# Altium schematic sheet USART_CAN.SchDoc
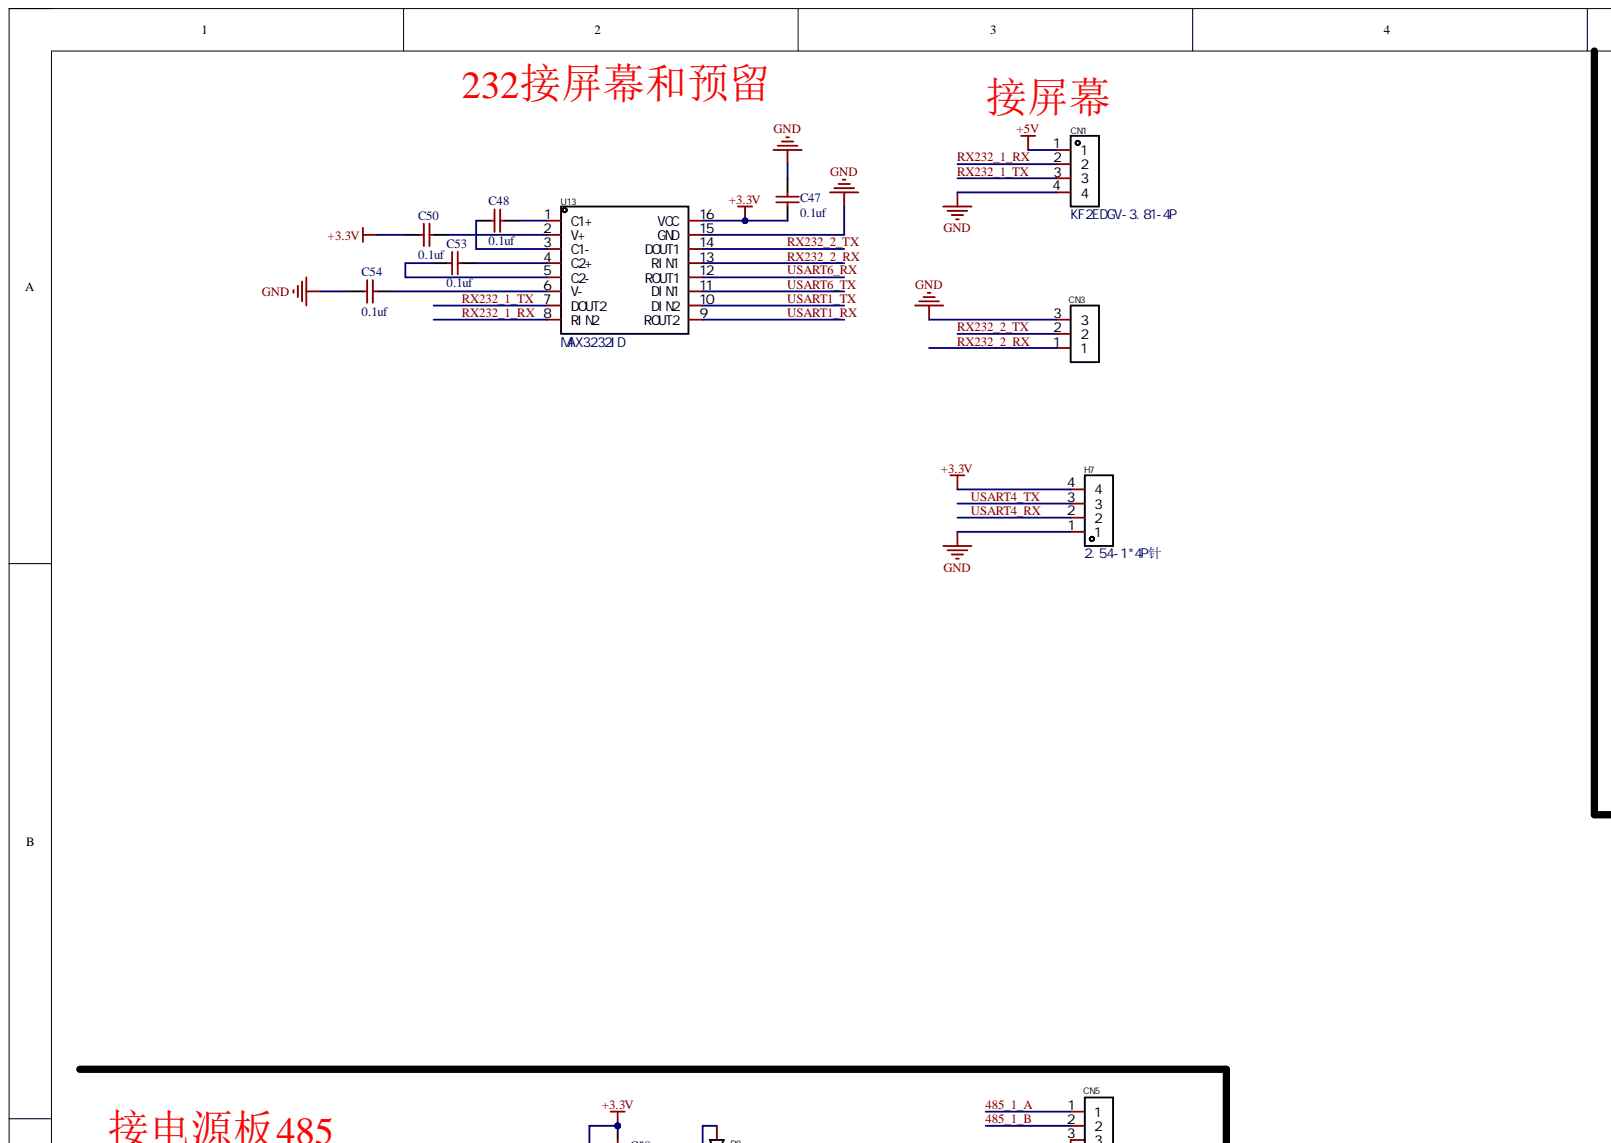
[diagram p0: USART_CAN.SchDoc, top left]
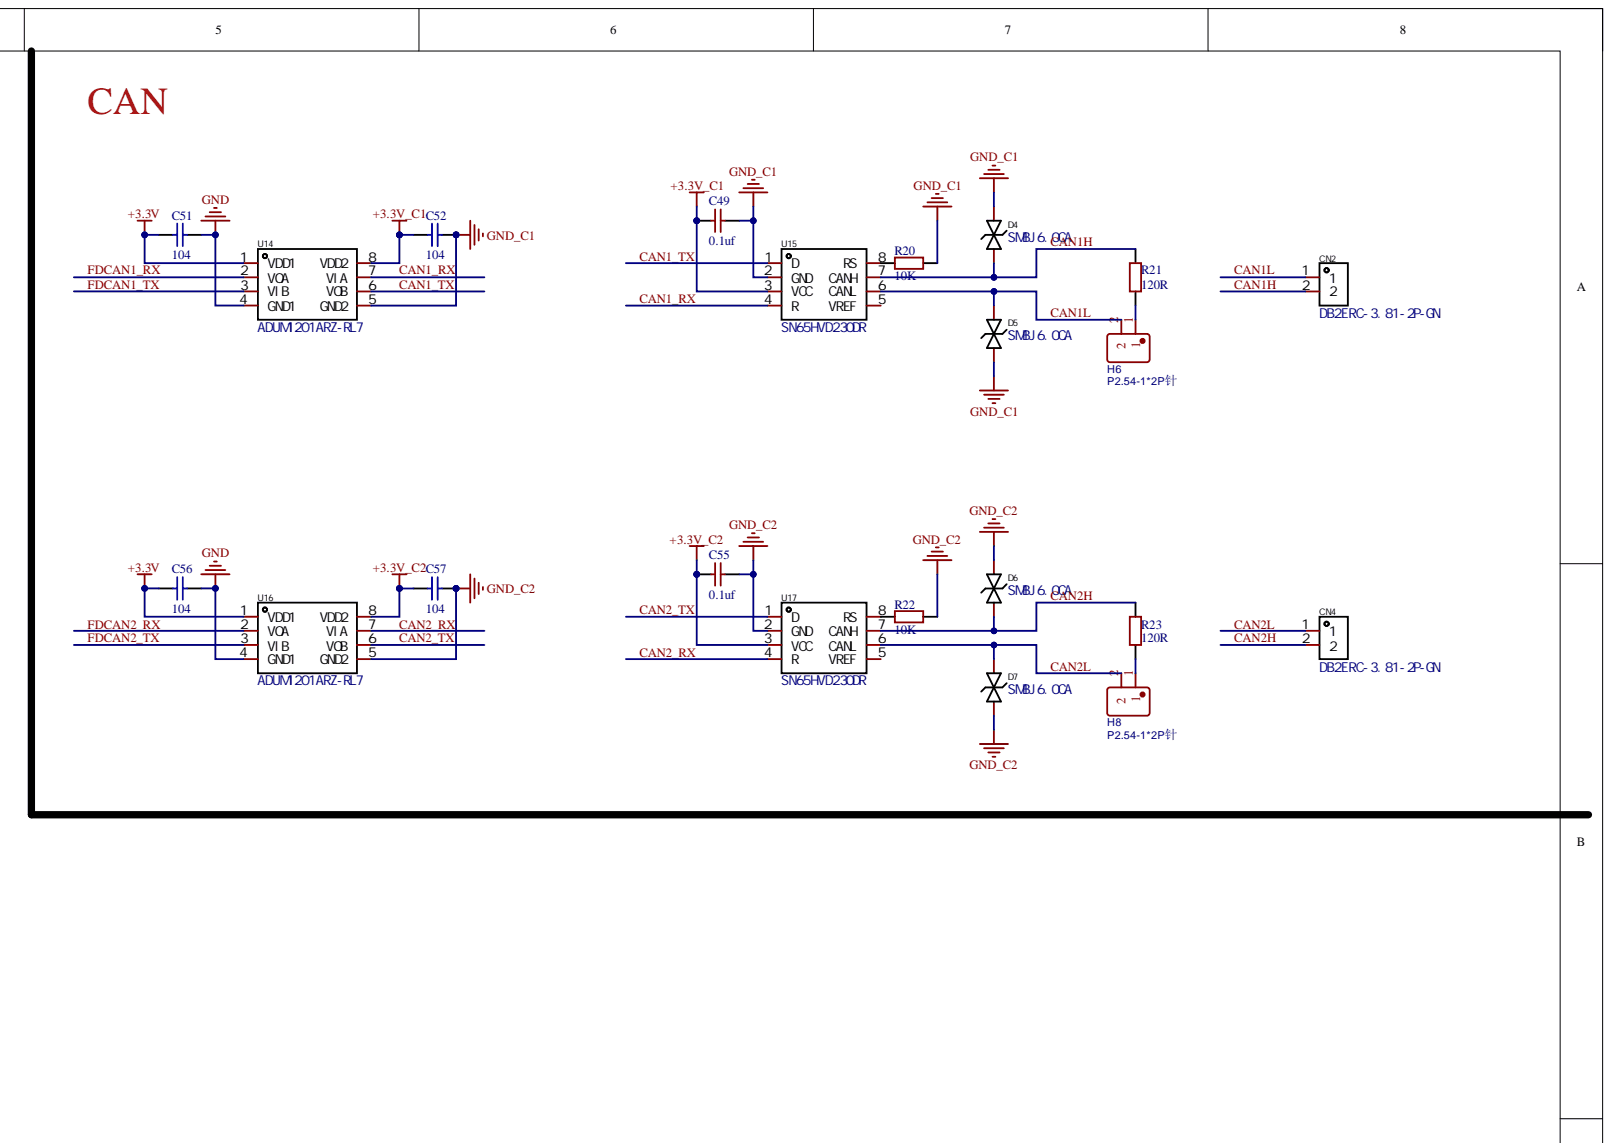
[diagram p1: USART_CAN.SchDoc, top right]
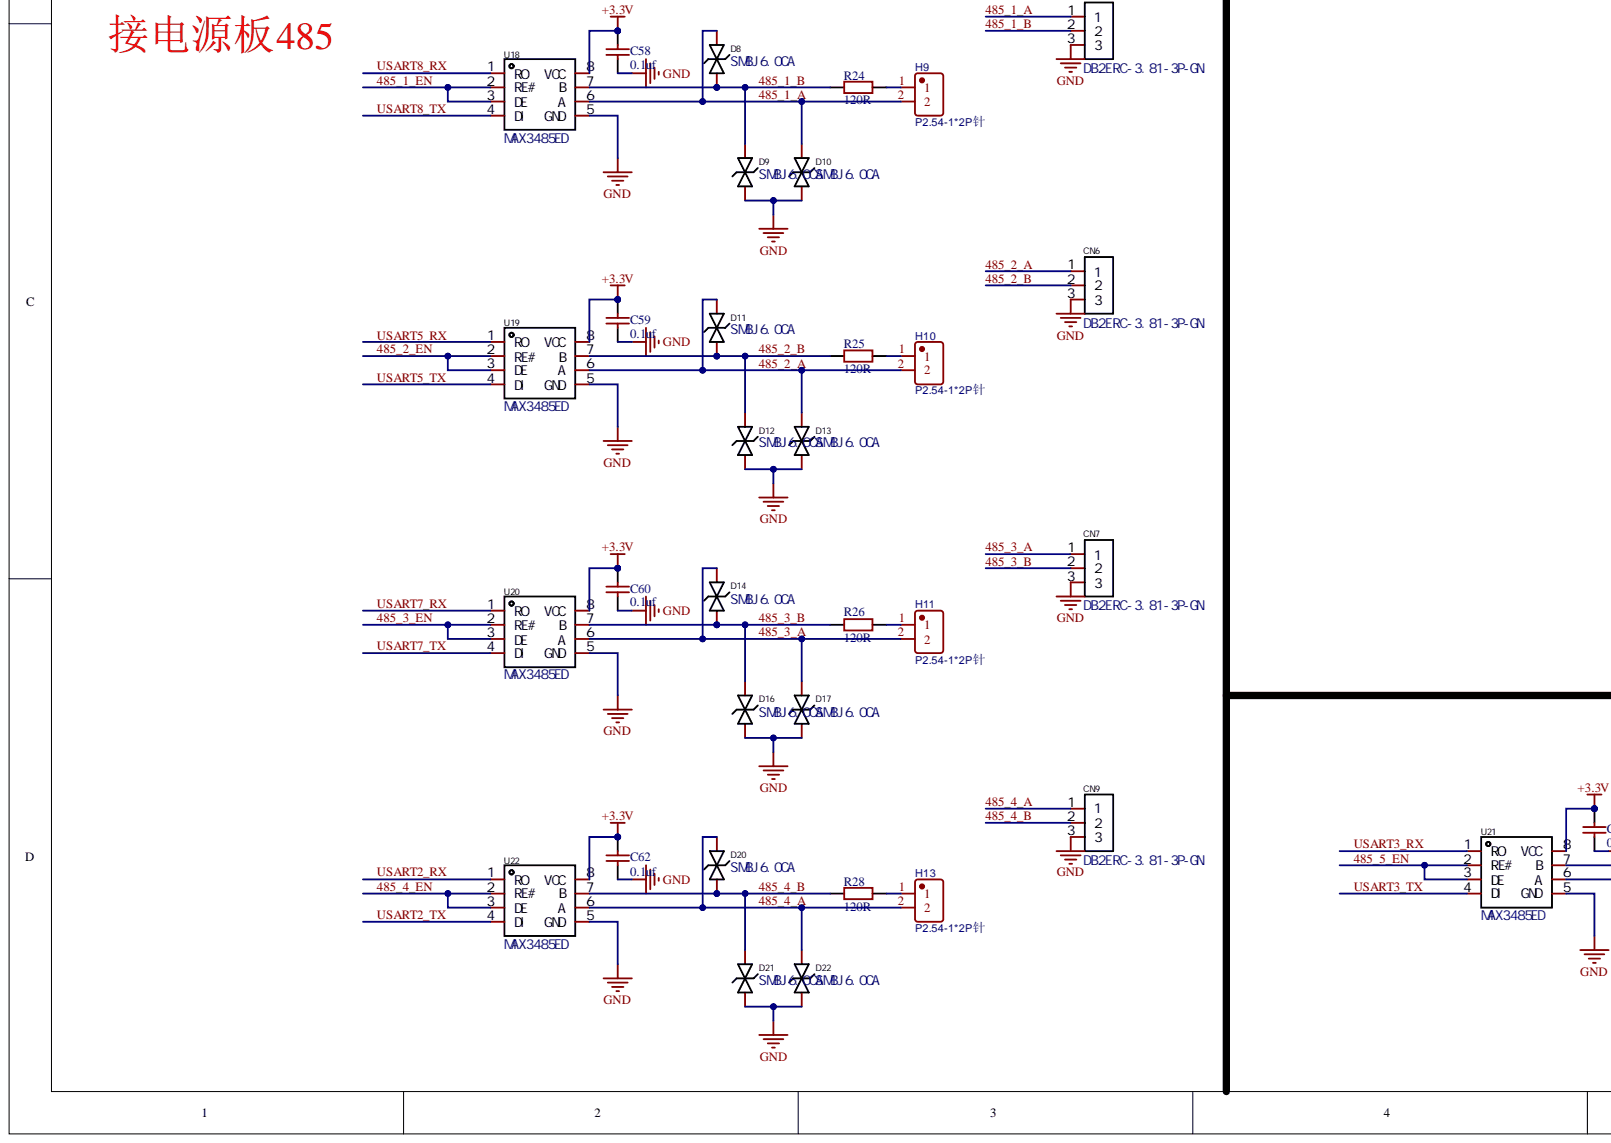
[diagram p2: USART_CAN.SchDoc, bottom left]
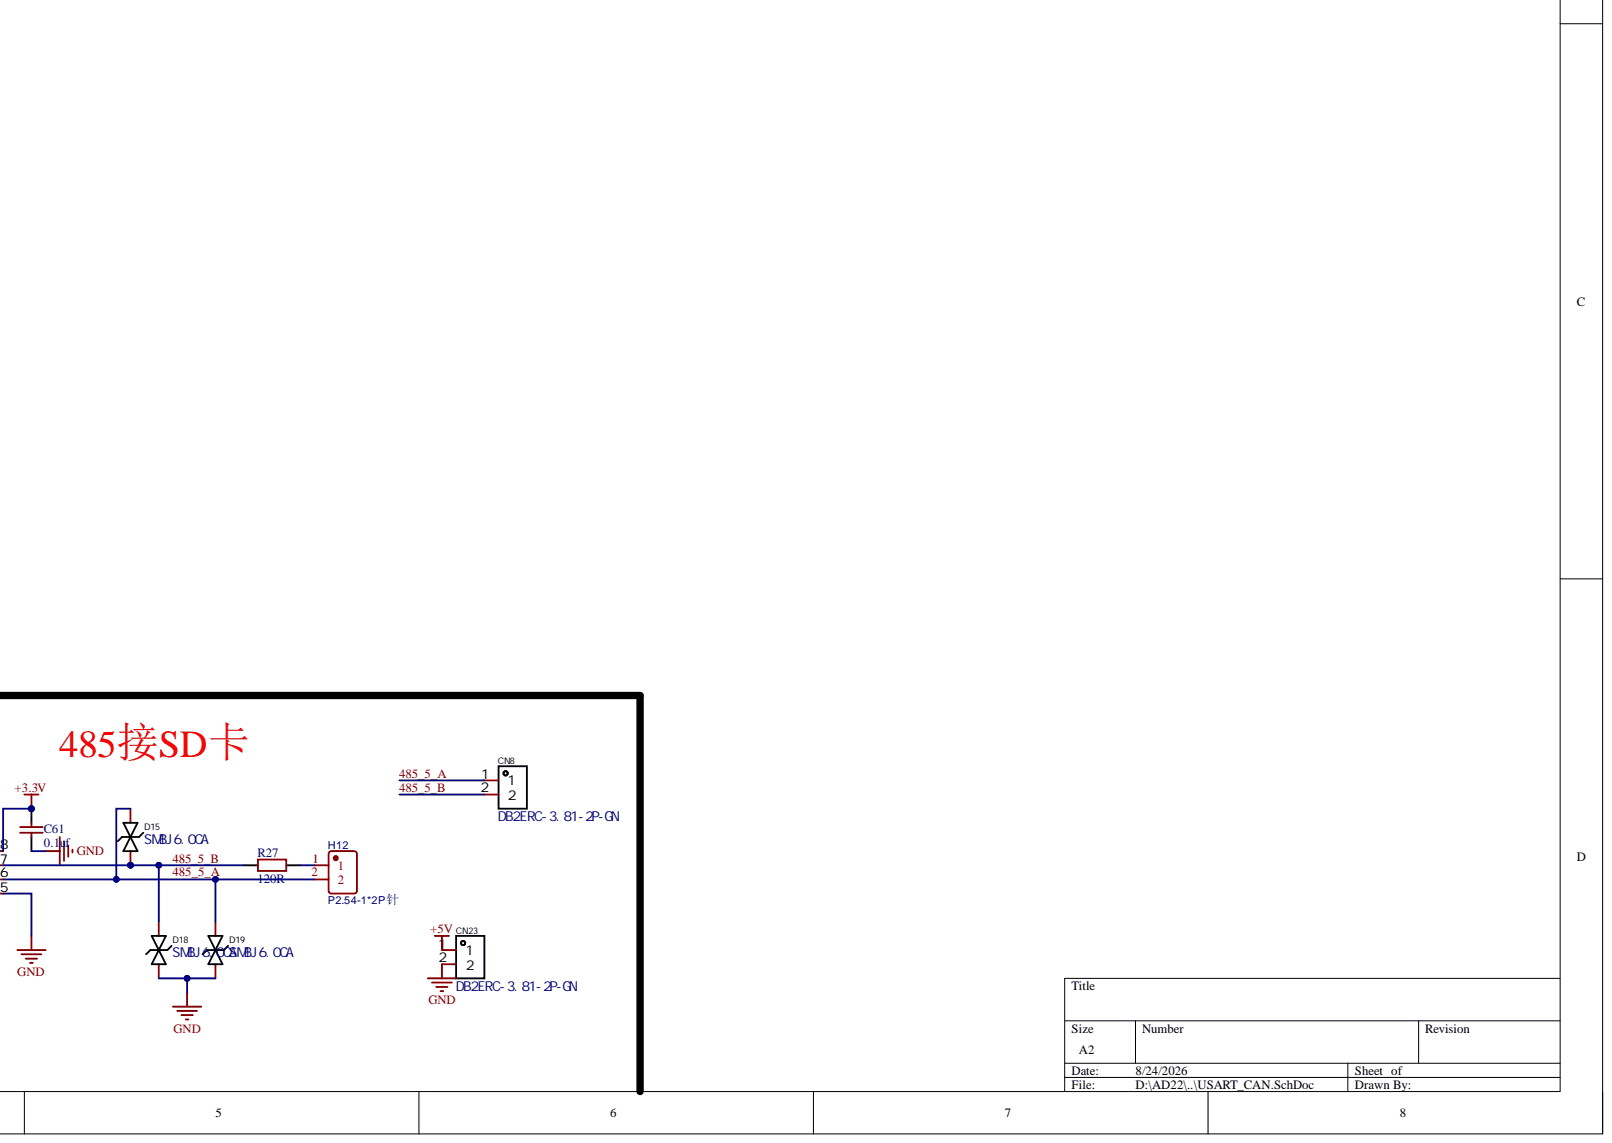
[diagram p3: USART_CAN.SchDoc, bottom right]

## Parts
C47  0.1uf  [0805_C]
C48  0.1uf  [0805_C]
C49  0.1uf  [0805_C]
C50  0.1uf  [0805_C]
C51  104  [0805_C]
C52  104  [0805_C]
C53  0.1uf  [0805_C]
C54  0.1uf  [0805_C]
C55  0.1uf  [0805_C]
C56  104  [0805_C]
C57  104  [0805_C]
C58  0.1uf  [0805_C]
C59  0.1uf  [0805_C]
C60  0.1uf  [0805_C]
C61  0.1uf  [0805_C]
C62  0.1uf  [0805_C]
CN1  KF2EDGV-3.81-4P  [CONN-TH_4P-P3.81_KF2EDGV-3.81-4P]
CN2  DB2ERC-3.81-2P-GN  [CONN-TH_2P-P3.81_DB2ERC-3.81-2P]
CN3  (no value)  [CONN-TH_3P-P3.81_DB2ERC-3.81-3P]
CN4  DB2ERC-3.81-2P-GN  [CONN-TH_2P-P3.81_DB2ERC-3.81-2P]
CN5  DB2ERC-3.81-3P-GN  [CONN-TH_3P-P3.81_DB2ERC-3.81-3P]
CN6  DB2ERC-3.81-3P-GN  [CONN-TH_3P-P3.81_DB2ERC-3.81-3P]
CN7  DB2ERC-3.81-3P-GN  [CONN-TH_3P-P3.81_DB2ERC-3.81-3P]
CN8  DB2ERC-3.81-2P-GN  [CONN-TH_2P-P3.81_DB2ERC-3.81-2P]
CN9  DB2ERC-3.81-3P-GN  [CONN-TH_3P-P3.81_DB2ERC-3.81-3P]
CN23  DB2ERC-3.81-2P-GN  [CONN-TH_2P-P3.81_DB2ERC-3.81-2P]
D4  SMBJ6.0CA  [SMB_L4.4-W3.6-LS5.3-BI]
D5  SMBJ6.0CA  [SMB_L4.4-W3.6-LS5.3-BI]
D6  SMBJ6.0CA  [SMB_L4.4-W3.6-LS5.3-BI]
D7  SMBJ6.0CA  [SMB_L4.4-W3.6-LS5.3-BI]
D8  SMBJ6.0CA  [SMB_L4.4-W3.6-LS5.3-BI]
D9  SMBJ6.0CA  [SMB_L4.4-W3.6-LS5.3-BI]
D10  SMBJ6.0CA  [SMB_L4.4-W3.6-LS5.3-BI]
D11  SMBJ6.0CA  [SMB_L4.4-W3.6-LS5.3-BI]
D12  SMBJ6.0CA  [SMB_L4.4-W3.6-LS5.3-BI]
D13  SMBJ6.0CA  [SMB_L4.4-W3.6-LS5.3-BI]
D14  SMBJ6.0CA  [SMB_L4.4-W3.6-LS5.3-BI]
D15  SMBJ6.0CA  [SMB_L4.4-W3.6-LS5.3-BI]
D16  SMBJ6.0CA  [SMB_L4.4-W3.6-LS5.3-BI]
D17  SMBJ6.0CA  [SMB_L4.4-W3.6-LS5.3-BI]
D18  SMBJ6.0CA  [SMB_L4.4-W3.6-LS5.3-BI]
D19  SMBJ6.0CA  [SMB_L4.4-W3.6-LS5.3-BI]
D20  SMBJ6.0CA  [SMB_L4.4-W3.6-LS5.3-BI]
D21  SMBJ6.0CA  [SMB_L4.4-W3.6-LS5.3-BI]
D22  SMBJ6.0CA  [SMB_L4.4-W3.6-LS5.3-BI]
H6  P2.54-1*2P针  [HDR-TH_2P-P2.54-V-M]
H7  2.54-1*4P针  [HDR-TH_4P-P2.54-V-M]
H8  P2.54-1*2P针  [HDR-TH_2P-P2.54-V-M]
H9  P2.54-1*2P针  [HDR-TH_2P-P2.54-V-M]
H10  P2.54-1*2P针  [HDR-TH_2P-P2.54-V-M]
H11  P2.54-1*2P针  [HDR-TH_2P-P2.54-V-M]
H12  P2.54-1*2P针  [HDR-TH_2P-P2.54-V-M]
H13  P2.54-1*2P针  [HDR-TH_2P-P2.54-V-M]
R20  10K  [0805_R]
R21  120R  [0805_R]
R22  10K  [0805_R]
R23  120R  [0805_R]
R24  120R  [0805_R]
R25  120R  [0805_R]
R26  120R  [0805_R]
R27  120R  [0805_R]
R28  120R  [0805_R]
U13  MAX3232ID  [SOIC-16_L9.9-W3.9-P1.27-LS6.0-BL]
U14  ADUM1201ARZ-RL7  [SOIC-8_L4.9-W3.9-P1.27-LS6.0-BL]
U15  SN65HVD230DR  [SOIC-8_L4.9-W3.9-P1.27-LS6.0-BL]
U16  ADUM1201ARZ-RL7  [SOIC-8_L4.9-W3.9-P1.27-LS6.0-BL]
U17  SN65HVD230DR  [SOIC-8_L4.9-W3.9-P1.27-LS6.0-BL]
U18  MAX3485ED  [SOP-8_L4.9-W3.9-P1.27-LS6.0-BL]
U19  MAX3485ED  [SOP-8_L4.9-W3.9-P1.27-LS6.0-BL]
U20  MAX3485ED  [SOP-8_L4.9-W3.9-P1.27-LS6.0-BL]
U21  MAX3485ED  [SOP-8_L4.9-W3.9-P1.27-LS6.0-BL]
U22  MAX3485ED  [SOP-8_L4.9-W3.9-P1.27-LS6.0-BL]

## Nets
+3.3V: C47.2 C50.2 C51.2 C56.2 C58.1 C59.1 C60.1 C61.1 C62.1 H7.4 U13.16(VCC) U14.1(VDD1) U16.1(VDD1) U18.8(VCC) U19.8(VCC) U20.8(VCC) U21.8(VCC) U22.8(VCC)
+3.3V_C1: C49.2 C52.2 U14.8(VDD2) U15.3(VCC)
+3.3V_C2: C55.2 C57.2 U16.8(VDD2) U17.3(VCC)
+5V: CN1.1 CN23.1
GND: C47.1 C51.1 C54.2 C56.1 C58.2 C59.2 C60.2 C61.2 C62.2 CN1.4 CN3.3 CN5.3 CN6.3 CN7.3 CN9.3 CN23.2 D9.1 D10.1 D12.1 D13.1 D16.1 D17.1 D18.1 D19.1 D21.1 D22.1 H7.1 U13.15(GND) U14.4(GND1) U16.4(GND1) U18.5(GND) U19.5(GND) U20.5(GND) U21.5(GND) U22.5(GND)
GND_C1: C49.1 C52.1 D4.2 D5.1 R20.1 U14.5(GND2) U15.2(GND)
GND_C2: C55.1 C57.1 D6.2 D7.1 R22.1 U16.5(GND2) U17.2(GND)
485_1_A: CN5.1 D8.2 D10.2 H9.2 U18.6(A)
485_1_B: CN5.2 D8.1 D9.2 R24.1 U18.7(B)
485_1_EN: U18.2(RE#) U18.3(DE)
485_2_A: CN6.1 D11.2 D13.2 H10.2 U19.6(A)
485_2_B: CN6.2 D11.1 D12.2 R25.1 U19.7(B)
485_2_EN: U19.2(RE#) U19.3(DE)
485_3_A: CN7.1 D14.2 D17.2 H11.2 U20.6(A)
485_3_B: CN7.2 D14.1 D16.2 R26.1 U20.7(B)
485_3_EN: U20.2(RE#) U20.3(DE)
485_4_A: CN9.1 D20.2 D22.2 H13.2 U22.6(A)
485_4_B: CN9.2 D20.1 D21.2 R28.1 U22.7(B)
485_4_EN: U22.2(RE#) U22.3(DE)
485_5_A: CN8.1 D15.2 D19.2 H12.2 U21.6(A)
485_5_B: CN8.2 D15.1 D18.2 R27.1 U21.7(B)
485_5_EN: U21.2(RE#) U21.3(DE)
CAN1_RX: U14.7(VIA) U15.4(R)
CAN1_TX: U14.6(VOB) U15.1(D)
CAN1H: CN2.2 D4.1 R21.2 U15.7(CANH)
CAN1L: CN2.1 D5.2 H6.2 U15.6(CANL)
CAN2_RX: U16.7(VIA) U17.4(R)
CAN2_TX: U16.6(VOB) U17.1(D)
CAN2H: CN4.2 D6.1 R23.2 U17.7(CANH)
CAN2L: CN4.1 D7.2 H8.2 U17.6(CANL)
FDCAN1_RX: U14.2(VOA)
FDCAN1_TX: U14.3(VIB)
FDCAN2_RX: U16.2(VOA)
FDCAN2_TX: U16.3(VIB)
RX232_1_RX: CN1.2 U13.8(RIN2)
RX232_1_TX: CN1.3 U13.7(DOUT2)
RX232_2_RX: CN3.1 U13.13(RIN1)
RX232_2_TX: CN3.2 U13.14(DOUT1)
USART1_RX: U13.9(ROUT2)
USART1_TX: U13.10(DIN2)
USART2_RX: U22.1(RO)
USART2_TX: U22.4(DI)
USART3_RX: U21.1(RO)
USART3_TX: U21.4(DI)
USART4_RX: H7.2
USART4_TX: H7.3
USART5_RX: U19.1(RO)
USART5_TX: U19.4(DI)
USART6_RX: U13.12(ROUT1)
USART6_TX: U13.11(DIN1)
USART7_RX: U20.1(RO)
USART7_TX: U20.4(DI)
USART8_RX: U18.1(RO)
USART8_TX: U18.4(DI)
NetC48_1: C48.1 U13.1(C1+)
NetC48_2: C48.2 U13.3(C1-)
NetC50_1: C50.1 U13.2(V+)
NetC53_1: C53.1 U13.4(C2+)
NetC53_2: C53.2 U13.5(C2-)
NetC54_1: C54.1 U13.6(V-)
NetH6_1: H6.1 R21.1
NetH8_1: H8.1 R23.1
NetH9_1: H9.1 R24.2
NetH10_1: H10.1 R25.2
NetH11_1: H11.1 R26.2
NetH12_1: H12.1 R27.2
NetH13_1: H13.1 R28.2
NetR20_2: R20.2 U15.8(RS)
NetR22_2: R22.2 U17.8(RS)

Not connected: U15 5(VREF); U17 5(VREF)

## Library data of the parts
C47-C50 C53-C55 C58-C62 = C 0603 1.0pF (010) 0.25pF 50V: 贴片电容; Component Kind: Standard; ComponentLink1Description: 供应商链接; ComponentLink1URL: http://www.szlcsc.com/product/details_24709.html; Library Name: BasicComponents2205.IntLib; Package: 0603; Supplier: LC; SuppliersPartNumber: C23969
C51 C52 C56 C57 = 0805 100nF(104) 10% 50V: 贴片电容; Component Kind: Standard; ComponentLink1Description: 供应商链接; ComponentLink1URL: http://www.szlcsc.com/product/details_50686.html; Package: 0805; Supplier: LC; SuppliersPartNumber: C49678; Library Name: JiaLiChuang.IntLib
CN1 = KF2EDGV-3.81-4P: 插拔式接线端子3.81 立式; Manufacturer: KEFA(科发); Add into BOM: yes; Connector Type: 板端/插座-闭口; Current Rating (Max): 8A; Device: KF2EDGV-3.81-4P_C441334; Number of PINs Per Row: 4; Pitch: 3.81mm; Structure: 1x4P; Voltage Rating (Max): 300V; Color: 绿色; Component Kind: Standard; Convert to PCB: yes; Datasheet: https://atta.szlcsc.com/upload/public/pdf/source/20230331/99AB14A2E5FD5FFB40E14C447652EA65.pdf; JLCPCB Part Class: Extended Part; LCSC Part Name: 3.81mm 1x4P 排数:1 每排P数:4; Library Name: pro24.IntLib; Manufacturer Part: KF2EDGV-3.81-4P; Mounting Style: 直针; Name: KF2EDGV-3.81-4P; Number of Pins: 4P; Number of Rows: 1; Operating Temperature Range: -40℃~+105℃; Supplier: LCSC; Supplier Footprint: 插件,P=3.81mm; Supplier Part: C441334; Symbol: KF2EDGV-3.81-4P
CN2 CN4 CN8 CN23 = DB2ERC-3.81-2P-GN: 插拔式接线端子3.81 立式/卧式; Manufacturer: DIBO(地博电气); Add into BOM: yes; Connector Type: 板端/插座-闭口; Current Rating (Max): 10A; Number of PINs Per Row: 2; Pitch: 3.81mm; Structure: 1x2P; Voltage Rating (Max): 250V; Color: 绿色; Component Kind: Standard; Convert to PCB: yes; Datasheet: https://atta.szlcsc.com/upload/public/pdf/source/20221115/4E217E7D538220F8720C137D6A05E519.pdf; Device: DB2ERC-3.81-2P-GN; JLCPCB Part Class: Extended Part; LCSC Part Name: 板端/插座-闭口 1x2P 3.81mm 排数:1 每排P数:2 弯针; Library Name: pro24.IntLib; Manufacturer Part: DB2ERC-3.81-2P-GN; Mounting Style: 弯针; Name: DB2ERC-3.81-2P-GN; Number of Rows: 1; Operating Temperature Range: -40℃~+105℃; Supplier: LCSC; Supplier Footprint: 弯插,P=3.81mm; Supplier Part: C395684; Symbol: DB2ERC-3.81-2P
CN3 CN5-CN7 CN9 = DB2ERC-3.81-3P-GN: 插拔式接线端子3.81 立式/卧式; Manufacturer: DIBO(地博电气); Add into BOM: yes; Connector Type: 板端/插座-闭口; Current Rating (Max): 10A; Device: DB2ERC-3.81-2P-GN; Number of PINs Per Row: 2; Pitch: 3.81mm; Structure: 1x2P; Symbol: DB2ERC-3.81-2P; Voltage Rating (Max): 250V; Color: 绿色; Component Kind: Standard; Convert to PCB: yes; Datasheet: https://atta.szlcsc.com/upload/public/pdf/source/20221115/4E217E7D538220F8720C137D6A05E519.pdf; JLCPCB Part Class: Extended Part; LCSC Part Name: 板端/插座-闭口 1x2P 3.81mm 排数:1 每排P数:2 弯针; Library Name: pro24.IntLib; Manufacturer Part: DB2ERC-3.81-2P-GN; Mounting Style: 弯针; Name: DB2ERC-3.81-2P-GN; Number of Rows: 1; Operating Temperature Range: -40℃~+105℃; Supplier: LCSC; Supplier Footprint: 弯插,P=3.81mm; Supplier Part: C395684
D4-D22 = SMBJ6.0CA: 瞬态抑制二极管(TVS) 双向; Manufacturer: TWGMC(台湾迪嘉); Add into BOM: yes; Device: SMBJ6.0CA_C726852; Component Kind: Standard; Convert to PCB: yes; Datasheet: https://atta.szlcsc.com/upload/public/pdf/source/20200901/C726811_07201DC3516E92FF13903A0BAE18F725.pdf; JLCPCB Part Class: Extended Part; LCSC Part Name: SMBJ6.0CA; Library Name: pro24.IntLib; Manufacturer Part: SMBJ6.0CA; Name: SMBJ6.0CA; Supplier: LCSC; Supplier Footprint: SMB; Supplier Part: C726852; Symbol: SMBJ6.0CA_C726852
H6 H8-H13 = P2.54-1*2P针: https://list.szlcsc.com/catalog/644.html; Component Kind: Standard; Library Name: BasicComponents2205.IntLib
H7 = 2.54-1*4P针: 排针; Manufacturer: XFCN(兴飞); Add into BOM: yes; Circluar Pins/Square Pins: 方针; Current Rating (Max): 3A; Device: PZ254V-11-04P; Pin Structure: 1x4P; Pitch: 2.54mm; Symbol: PZ254V-11-04P_C2691448; Voltage Rating (Max): 250V; Color: 黑色; Component Kind: Standard; Contact Material: -; Convert to PCB: yes; Datasheet: https://atta.szlcsc.com/upload/public/pdf/source/20200309/C492403_F9F19131791D08044AD4F84F8E751A34.pdf; Insulation Height: 2.5mm; JLCPCB Part Class: Extended Part; LCSC Part Name: 2.54mm 1x4P 直插 方针; Length of End Connection Pin: 3mm; Length of Mating Pin: 6mm; Manufacturer Part: PZ254V-11-04P; Mounting Type: 直插; Name: PZ254V-11-04P; Number of Pins: 4; Number of Rows: 单排; Operating Temperature Range: -40℃~+105℃; Row Spacing: -; Supplier: LCSC; Supplier Footprint: 直插,P=2.54mm; Supplier Part: C2691448; Library Name: WXcharge0210.IntLib
R20-R28 = R 0603 0Ω (0R0) 1%: 贴片电阻; Component Kind: Standard; ComponentLink1Description: 供应商链接; ComponentLink1URL: http://www.szlcsc.com/product/details_21903.html; Library Name: BasicComponents2205.IntLib; Package: 0603; Supplier: LC; SuppliersPartNumber: C21189
U13 = MAX3232ID: 232; Manufacturer: TI(德州仪器); Add into BOM: yes; Data Rate: 250Kbps; Driver/receiver: 2/2; Supply Voltage: 3V~5.5V; Types: 收发器; Component Kind: Standard; Convert to PCB: yes; Datasheet: https://atta.szlcsc.com/upload/public/pdf/source/20190123/C354119_D99139729616ADF6E4914C09484DF890.pdf; Device: MAX3232ID; JLCPCB Part Class: Extended Part; LCSC Part Name: 收发器 250Kbps; Manufacturer Part: MAX3232ID; Name: MAX3232ID; Supplier: LCSC; Supplier Footprint: SOIC-16; Supplier Part: C354119; Symbol: MAX3232ID; Library Name: WXcharge0210.IntLib
U14 U16 = ADUM1201ARZ-RL7: 数字隔离器; Manufacturer: ADI(亚德诺)/LINEAR(凌特); Add into BOM: yes; Data Rate: 1Mbps; Forward Channel: 1; Isolation Technology: 磁隔离; Isolation voltage: 2500Vrms; Number of Channels: 2; Supply Voltage: 2.7V~5.5V; Voltage Isolation or Not: 带电压隔离; Applications: 通用; Component Kind: Standard; Convert to PCB: yes; Datasheet: https://atta.szlcsc.com/upload/public/pdf/source/20121103/1457706544769.pdf; Device: ADUM1201ARZ-RL7; JLCPCB Part Class: Basic Part; LCSC Part Name: ADUM1201ARZ-RL7; Library Name: pro24.IntLib; Manufacturer Part: ADUM1201ARZ-RL7; Name: ADUM1201ARZ-RL7; Operating Temperature: -55℃~+100℃; Reverse channel: 1; Supplier: LCSC; Supplier Footprint: SOIC-8; Supplier Part: C9669; Symbol: ADUM1201ARZ-RL7
U15 U17 = SN65HVD230DR: CAN芯片3.3V; Manufacturer: TI(德州仪器); Add into BOM: yes; Data Rate: 1Mbps; Supply Voltage: 3V~3.6V; Component Kind: Standard; Convert to PCB: yes; Datasheet: https://atta.szlcsc.com/upload/public/pdf/source/20140508/1457707055452.pdf; Device: SN65HVD230DR; JLCPCB Part Class: Basic Part; LCSC Part Name: 1Mbps 3V~3.6V; Library Name: pro24.IntLib; Manufacturer Part: SN65HVD230DR; Name: SN65HVD230DR; Supplier: LCSC; Supplier Footprint: SOIC-8; Supplier Part: C12084; Symbol: SN65HVD230DR; Type: 收发器
U18-U22 = MAX3485ED: 485; Manufacturer: HTC; Add into BOM: yes; Component Kind: Standard; Convert to PCB: yes; Data Rate: -; Datasheet: https://atta.szlcsc.com/upload/public/pdf/source/20200522/C558792_9E3A5168BF220BB2C20A3F3D0A7BA0BA.pdf; Device: MAX3485ED; JLCPCB Part Class: Extended Part; LCSC Part Name: MAX3485ED; Manufacturer Part: MAX3485ED; Name: MAX3485ED; Number of Drivers/Receivers: -; Protocol: -; Supplier: LCSC; Supplier Footprint: SOP-8; Supplier Part: C558792; Supply Voltage: -; Symbol: MAX3485ED; Type: -; Library Name: WXcharge0210.IntLib
